# Altium schematic sheet POWER SWITCH AND LDO.SchDoc
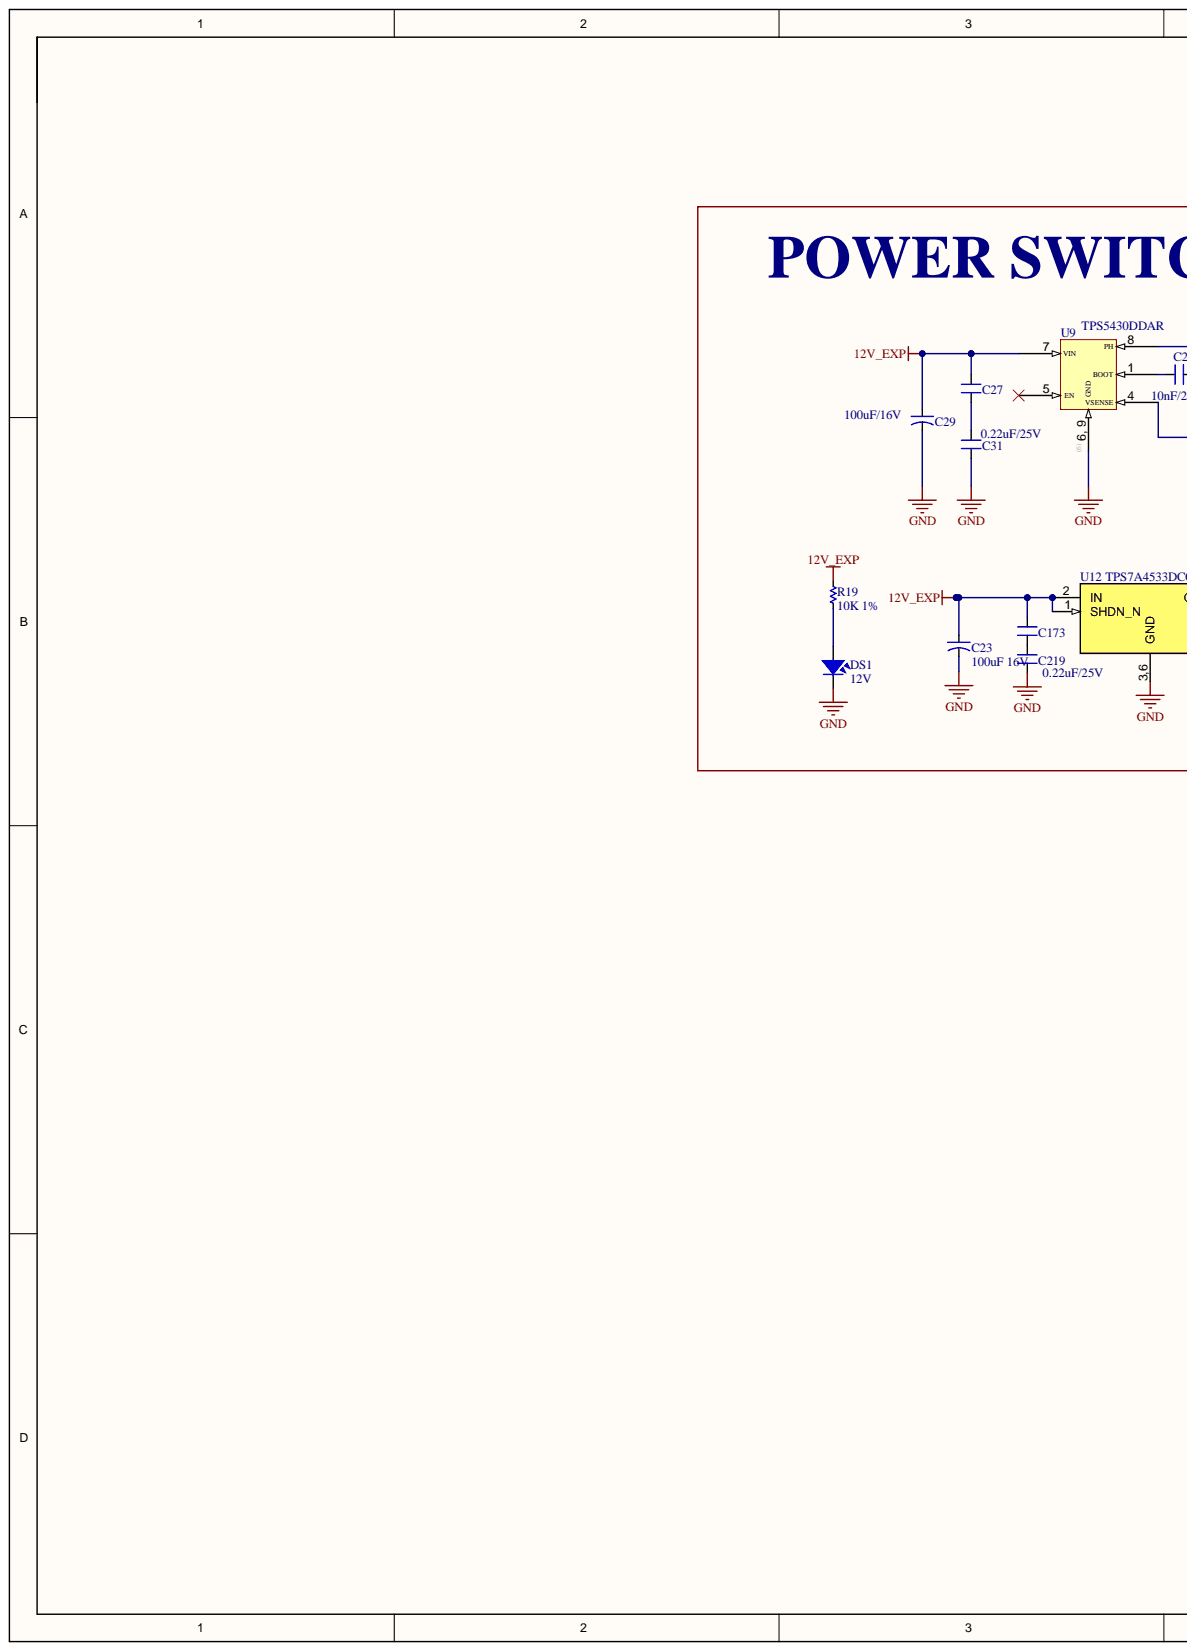
[diagram p0: POWER SWITCH AND LDO.SchDoc, left]
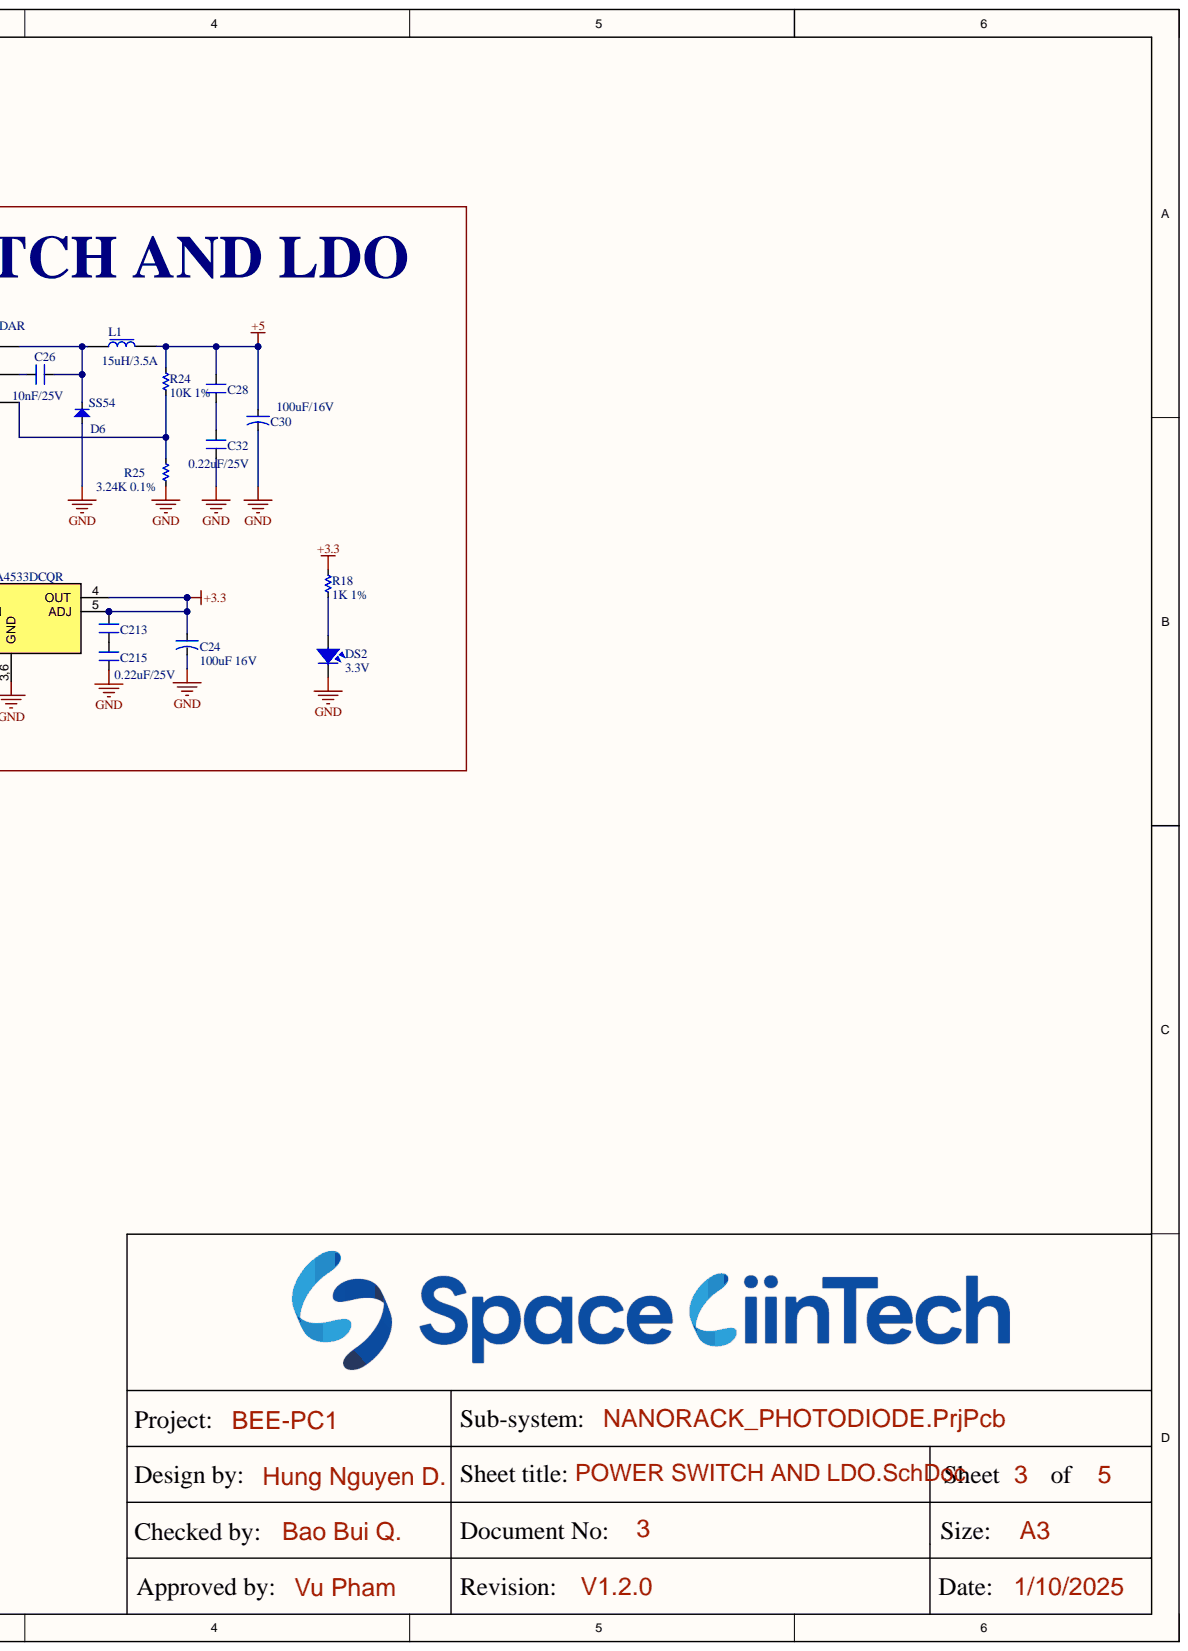
[diagram p1: POWER SWITCH AND LDO.SchDoc, right]

## Parts
C23  100uF 16V  [CAP TANT 2312]
C24  100uF 16V  [CAP TANT 2312]
C26  10nF/25V  [SM-0603]
C27  0.22uF/25V  [SM-0603]
C28  0.22uF/25V  [SM-0603]
C29  100uF/16V  [CAP TANT 2312]
C30  100uF/16V  [CAP TANT 2312]
C31  0.22uF/25V  [SM-0603]
C32  0.22uF/25V  [SM-0603]
C173  0.22uF/25V  [SM-0603]
C213  0.22uF/25V  [SM-0603]
C215  0.22uF/25V  [SM-0603]
C219  0.22uF/25V  [SM-0603]
D6  SS54  [DIO-SMD-A]
DS1  12V  [GREENLED_0603]
DS2  3.3V  [GREENLED_0603]
L1  15uH/3.5A  [SRP7050TA]
R18  1K 1%  [RES-SMD-0603]
R19  10K 1%  [RES-SMD-0603]
R24  10K 1%  [RES-SMD-0603]
R25  3.24K 0.1%  [RES-SMD-0603]
U9  TPS5430DDAR  [HSOP8]
U12  TPS7A4533DCQR  [SOT223-6]

## Nets
12V_EXP: C23.1 C27.2 C29.1 C173.2 R19.2 U9.7(VIN) U12.1(SHDN_N) U12.2(IN)
+3.3: C24.1 C213.2 R18.2 U12.4(OUT) U12.5(ADJ)
+5: C28.2 C30.1 L1.2 R24.2
GND: C23.2 C24.2 C29.2 C30.2 C31.1 C32.1 C215.1 C219.1 D6.1 DS1.2 DS2.2 R25.1 U9.6(GND) U12.3,6(GND)
NetC26_1: C26.1 D6.2 L1.1 U9.8(PH)
NetC26_2: C26.2 U9.1(BOOT)
NetC27_1: C27.1 C31.2
NetC28_1: C28.1 C32.2
NetC173_1: C173.1 C219.2
NetC213_1: C213.1 C215.2
NetDS1_1: DS1.1 R19.1
NetDS2_1: DS2.1 R18.1
NetR24_1: R24.1 R25.2 U9.4(VSENSE)

Not connected: U9 5(EN)

## Library data of the parts
C23 C24 C29 C30 = CN-ALU-SMD: Polarized Capacitor (Axial); Component Kind: Standard; LatestRevisionDate: 17-Jul-2002; LatestRevisionNote: Re-released for DXP Platform.; PackageReference: POLAR0.8; Published: 8-Jun-2000; Library Name: Library_HUNG.SchLib; Publisher: Altium Limited
C26-C28 C31 C32 C173 C213 C215 C219 = CM: 0603; Value: 103; Component Kind: Standard; Library Name: Capacitors.SchLib
D6 = Diode SMD: DIODE SCHOTTKY 40V 5A SMA; Code - JEDEC: DO-214-AB; Value: 1N5007; Component Kind: Standard; Library Name: Library.SchLib; Published: 8-Jun-2000; Revision: July-2002: Re-released for DXP Platform.; Publisher: Altium Limited
DS1 DS2 = LED SMD: Value: LED 3 - 5 ly 2 Colour; Component Kind: Standard; Library Name: Library.SchLib
L1 = INDUCTOR: SRP7050TA-150M; Component Kind: Standard; Library Name: Library.SchLib
R18 R19 R24 = Res-SMD: 0603; Manufacturer: Generic Components; Class: Passive; Component Kind: Standard; Library Name: Library.SchLib; Published: 08/06/2000; Publisher: Altium Hobart Technology Centre
R25 = Res-SMD: ERA-3AEB3241V; Manufacturer: Generic Components; Class: Passive; Component Kind: Standard; Library Name: Library.SchLib; Published: 08/06/2000; Publisher: Altium Hobart Technology Centre
U9 = TPS543X: 8SOP; Component Kind: Standard; Library Name: Library.SchLib
U12 = TPS7A4533DCQR: IC REG LINEAR 3.3V 1.5A SOT223-6; Component Kind: Standard; Library Name: Library.SchLib
